# Altium schematic sheet 2_Power.SchDoc(U_2_Power)
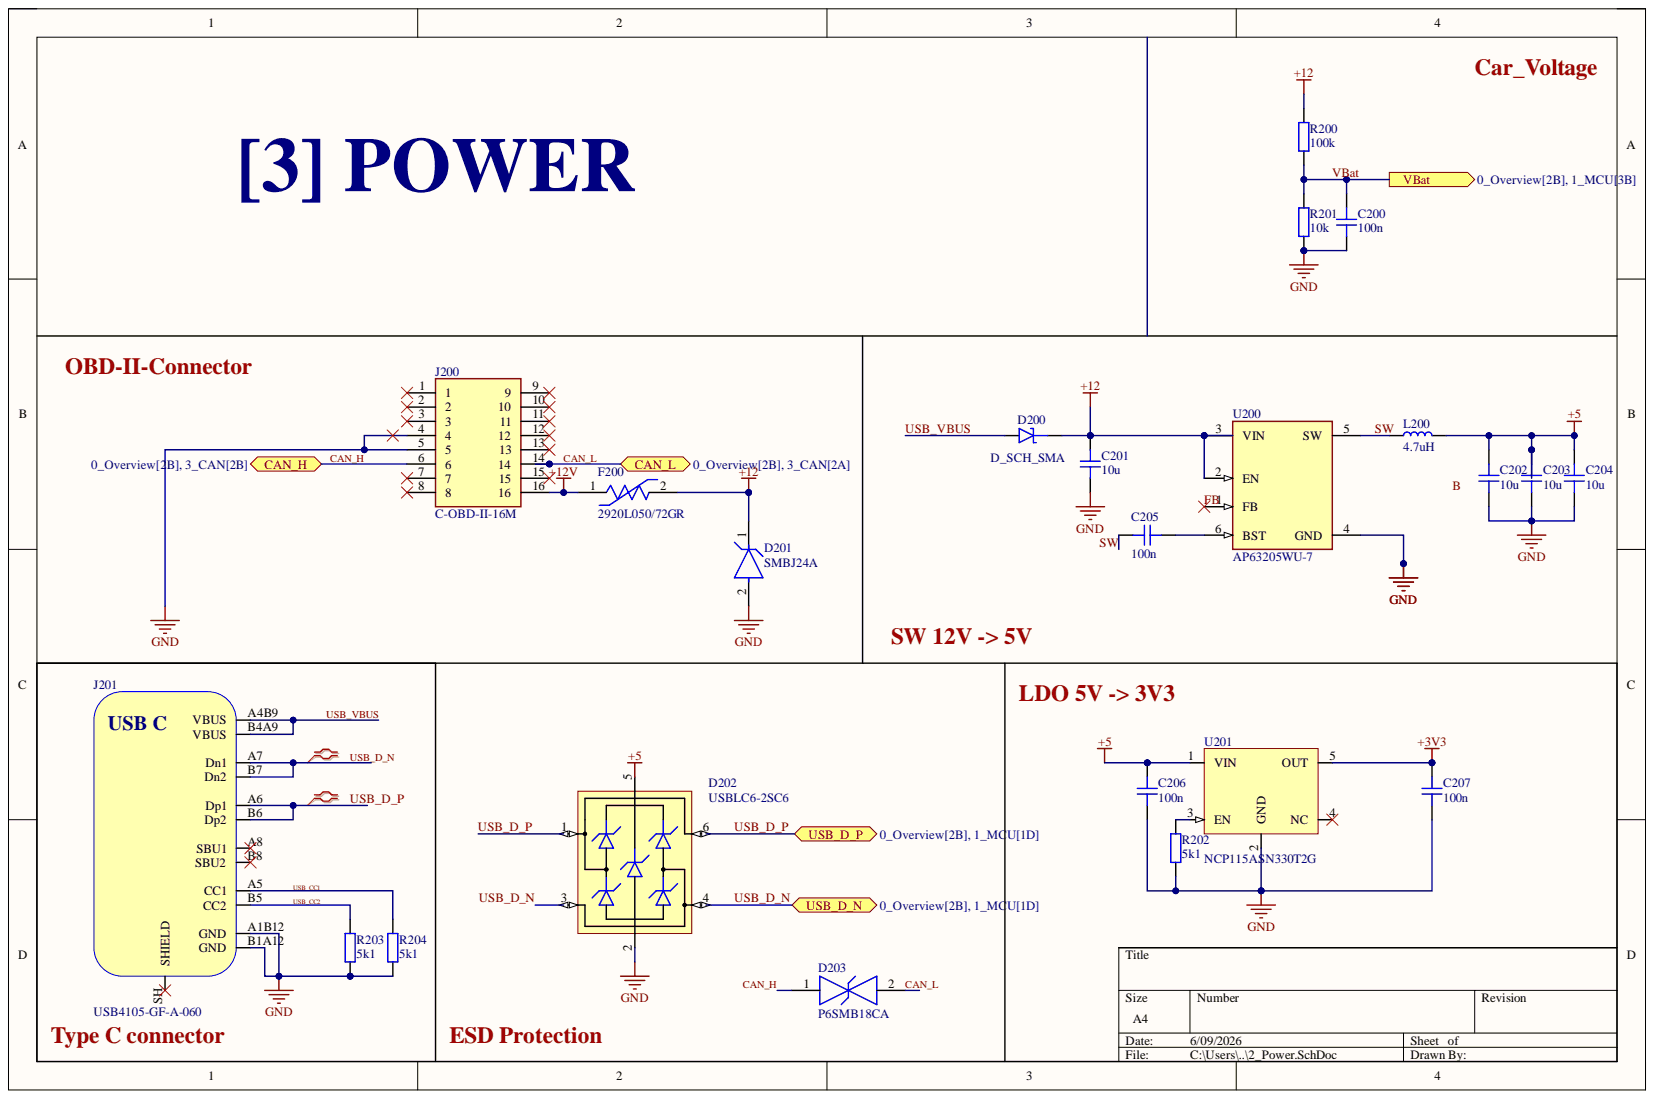
[diagram p0: 2_Power.SchDoc]

## Parts
C200  100n  [CAP_0805]
C201  10u  [CAP_0805]
C202  10u  [CAP_0805]
C203  10u  [CAP_0805]
C204  10u  [CAP_0805]
C205  100n  [CAP_0603]
C206  100n  [CAP_0603]
C207  100n  [CAP_0603]
D200  D_SCH_SMA  [DO-214AC-2 (SMA)]
D201  SMBJ24A  [FP-SMBJ-MFG]
D202  USBLC6-2SC6  [SOT-23-6]
D203  P6SMB18CA  [FP-P6SMB-CA-MFG]
F200  2920L050/72GR  [FP-2920L-MFG]
J200  C-OBD-II-16M  [COMTECH_C-OBD-II-16M]
J201  USB4105-GF-A-060  [USB4105-GF-A-060]
L200  4.7uH  [ASPI-4030S]
R200  100k  [RES_0805]
R201  10k  [RES_0805]
R202  5k1  [RES_0603]
R203  5k1  [RES_0603]
R204  5k1  [RES_0603]
U200  AP63205WU-7  [FP-TSOT26-MFG]
U201  NCP115ASN330T2G  [TSOT25]

## Ports
CAN_H, CAN_L, USB_D_N, USB_D_P, VBat

## Nets
+3V3: C207.1 U201.5(OUT)
+5: C202.2 C203.2 C204.2 C206.1 D202.5 L200.2 U201.1(VIN)
+12: C201.2 D200.K D201.1 F200.2 R200.2 U200.2(EN) U200.3(VIN)
+12V: F200.1 J200.16
GND: C200.1 C201.1 C202.1 C203.1 C204.1 C206.2 C207.2 D201.2 D202.2 J200.4 J200.5 J201.A1B12(GND) J201.B1A12(GND) R201.1 R202.1 R203.1 R204.1 U200.4(GND) U201.2(GND)
CAN_H: D203.1 J200.6 ; port CAN_H
CAN_L: D203.2 J200.14 ; port CAN_L
FB: U200.1(FB)
SW: C205.2 L200.1 U200.5(SW)
USB_CC1: J201.A5(CC1) R204.2
USB_CC2: J201.B5(CC2) R203.2
USB_D_N: D202.3 D202.4 J201.A7(Dn1) J201.B7(Dn2) ; port USB_D_N
USB_D_P: D202.1 D202.6 J201.A6(Dp1) J201.B6(Dp2) ; port USB_D_P
USB_VBUS: D200.A J201.A4B9(VBUS) J201.B4A9(VBUS)
VBat: C200.2 R200.1 R201.2 ; port VBat
NetC205_1: C205.1 U200.6(BST)
NetJ200_1: J200.1
NetJ200_2: J200.2
NetJ200_3: J200.3
NetJ200_7: J200.7
NetJ200_8: J200.8
NetJ200_9: J200.9
NetJ200_10: J200.10
NetJ200_11: J200.11
NetJ200_12: J200.12
NetJ200_13: J200.13
NetJ200_15: J200.15
NetJ201_A8: J201.A8(SBU1)
NetJ201_B8: J201.B8(SBU2)
NetJ201_SH: J201.SH(SHIELD)
NetR202_2: R202.2 U201.3(EN)
NetU201_4: U201.4(NC)
B:

## Library data of the parts
C200-C204 = CAP_0805: Capacitor SMD 0805; Component Kind: Standard; Library Name: Schematic-Lib-PhilsLab.SchLib
C205-C207 = CAP_0603: Capacitor SMD 0603; Component Kind: Standard; Library Name: Schematic-Lib-PhilsLab.SchLib
D200 = D_SCHOTTKY_SMA: Diode Schottky SMD SMA (DO-214AA); Component Kind: Standard; Library Name: Schematic-Lib-PhilsLab.SchLib
D201 = CMP-07401-000035-1: TVS DIODE 24V 38.9V SMB; Breakdown Voltage: 30.7V; Diode Type: ZENER; Direction: Unidirectional; Element Configuration: Single; ESD Protection: Yes; Leakage Current: 5uA; Max Power Dissipation: 600W; Max Surge Current: 100A; Min Breakdown Voltage: 26.7V; Number of Channels: 1; Operating Supply Voltage: 3.5V; Peak Pulse Current: 15.4A; Power Line Protection: No; Test Current: 1mA; Case/Package: SMB; Clamping Voltage: 38.9V; Component Kind: Standard; Height: 2.42mm; Length: 4.57mm; Library Name: Altium Content Vault; Max Breakdown Voltage: 30.7V; Max Operating Temperature: 150°C; Max Reverse Leakage Current: 5uA; Min Operating Temperature: -55°C; Mount: Surface Mount; Number of Elements: 1; Number of Pins: 2; Number of Unidirectional Channels: 1; Package Quantity: 1; Packaging: Tape and Reel; Peak Pulse Power: 600W; Polarity: Unidirectional; Radiation Hardening: No; REACH SVHC: No SVHC; Resistor Type: Zener; Reverse Breakdown Voltage: 26.7V; Reverse Standoff Voltage: 24V; RoHS Compliant: Yes; Series: SMBJ; Termination: SMD/SMT; Width: 3.94mm
D202 = USBLC6-2SC6: Low-Capacitance ESD Protection USB; Manufacturer: STMicroelectronics; Component Kind: Standard; Distributor Link: https://www.mouser.de/ProductDetail/STMicroelectronics/USBLC6-2SC6?qs=po45yt2pPpu%2FhNIlwQdTlg%3D%3D; Manufacturer Part Number: USBLC6-2SC6; Library Name: Schematic-Lib-PhilsLab.SchLib
D203 = CMP-08607-000095-1: TVS DIODE 15.3V 25.5V DO214AA; Breakdown Voltage: 17.1V; Direction: Bidirectional; Leakage Current: 1uA; Max Breakdown Voltage: 18.9V; Max Power Dissipation: 5W; Max Surge Current: 24A; Number of Bidirectional Channels: 1; Peak Pulse Current: 24.2A; Peak Pulse Power: 600W; Power Line Protection: No; Test Current: 1mA; Case/Package: SMB; Clamping Voltage: 25.5V; Component Kind: Standard; Composition: Zener; Lead Free: Lead Free; Library Name: Altium Content Vault; Max Operating Temperature: 150°C; Min Breakdown Voltage: 17.1V; Min Operating Temperature: -55°C; Mount: Surface Mount; Number of Channels: 1; Number of Elements: 1; Number of Pins: 2; Operating Supply Voltage: 15.3V; Package Quantity: 3000; Packaging: Tape and Reel; Polarity: Bidirectional; Power Dissipation: 600W; Reverse Breakdown Voltage: 17.1V; Reverse Standoff Voltage: 15.3V; Termination: SMD/SMT
F200 = CMP-08606-000028-1: PTC RESET FUSE 60V 1.1A 2920; Manufacturer: Littelfuse; Manufacturer Part Number: 2920L110/60MR; Current Rating: 20A; Resistance: 0.12-0.41Ω; Trip Current: 2.2A; Trip Time: 0.5s; Value: 0.12-0.41Ω; Ambient Temperature Range High: +85°C; Ambient Temperature Range Low: -40°C; Automotive: No; Category: Fuse; Component Kind: Standard; ComponentLink2Description: Datasheet; ComponentLink2URL: https://m.littelfuse.com/~/media/electronics/datasheets/resettable_ptcs/littelfuse_ptc_2920l_datasheet.pdf.pdf; Device Class L1: Circuit Protection; Device Class L2: PTC Resettable Fuses; Device Class L3: unset; Digikey Description: PTC RESET FUSE 60V 1.1A 2920; Height: 2mm; Hold Current: 1.1A; Lead Free: Yes; Library Name: Altium Content Vault; Octopart: https://octopart.com/2920l110%2F60mr-littelfuse-75250824; Package: 2920L; RoHS: TRUE; Temperature: -40°C to +85°C; Temperature Range High: +85°C; Temperature Range Low: -40°C; Voltage Rating: 60V
J200 = CMP-002-00074-1: OBD II 16pin 90 Degree Angle Male Plug Connector; MF: Comtech Electronic Co., LTD; Case/Package: None; Check_prices: https://www.snapeda.com/parts/C-OBD-II-16M/Comtech+Electronic+Co.%252C+LTD/view-part/?ref=eda; Component Kind: Standard; Component Type: Standard; Design Item ID: C-OBD-II-16M; MP: C-OBD-II-16M; Pins: 16; SnapEDA_Link: https://www.snapeda.com/parts/C-OBD-II-16M/Comtech+Electronic+Co.%252C+LTD/view-part/?ref=snap; Source: C-OBD-II-16M.SchLib; Library Name: Ahmed ENP
J201 = USB4105-GF-A-060: USB Connector Type C SMT 12 Pin; Manufacturer: GCT; Component Kind: Standard; Distributor Link: https://eu.mouser.com/ProductDetail/GCT/USB4105-GF-A-060?qs=QNEnbhJQKvY2mocwNJzgfA%3D%3D; Manufacturer Part Number: USB4105-GF-A-060; Library Name: Schematic-Lib-PhilsLab.SchLib
L200 = ASPI-4030S: Power Inductor SMD ASPI-4030S Series; Manufacturer: ABRACON; Manufacturer Part Number: ASPI-4030S*; Component Kind: Standard; Library Name: Schematic-Lib-PhilsLab.SchLib
R200 R201 = RES_0805: Resistor SMD 0805; Component Kind: Standard; Library Name: Schematic-Lib-PhilsLab.SchLib
R202-R204 = RES_0603: Resistor SMD 0603; Component Kind: Standard; Library Name: Schematic-Lib-PhilsLab.SchLib
U200 = CMP-12757-000001-1: IC REG BUCK 5V 2A TSOT26; Manufacturer: Diodes Inc.; Max Duty Cycle: 100%; Max Junction Temp: +160°C; Max Supply Voltage: 32V; Min Supply Voltage: 3.8V; Nominal Supply Current: 22uA; Number Of Outputs: 1; Output Type: Fixed; Standoff Height: 0.01mm; Switching Frequency: 1100kHz; Ambient Temperature Range High: +85°C; Ambient Temperature Range Low: -40°C; Automotive: No; Category: IC; Component Kind: Standard; ComponentLink2Description: Datasheet; ComponentLink2URL: https://www.diodes.com/assets/Datasheets/AP63200-AP63201-AP63203-AP63205.pdf; Device Class L1: Integrated Circuits (ICs); Device Class L2: Power Management ICs; Device Class L3: Voltage Regulators - Switching; Digikey Description: IC REG BUCK 5V 2A TSOT26; Footprint Url: https://www.diodes.com/assets/Package-Files/TSOT26.pdf; Height: 1mm; Lead Free: Yes; Library Name: Altium Content Vault; Manufacturer Part Number: AP63205WU-7; Octopart: https://octopart.com/ap63205wu-7-diodes+inc.-98099551; Output Current: 2A; Output Voltage: 5V; Package: TSOT26; RoHS: TRUE; Switching Topology: Buck; Temperature: -40°C to +85°C; Temperature Range High: +85°C; Temperature Range Low: -40°C
U201 = NCP115ASN330T2G: LDO Regulator - High PSRR 300 mA 3.3V TSOP-5; Manufacturer: ON Semiconductor; Component Kind: Standard; Manufacturer Part Number: NCP115ASN330T2G; Library Name: Schematic-Lib-PhilsLab.SchLib
